# Altium schematic sheet Sheet2.SchDoc(Sheet2)
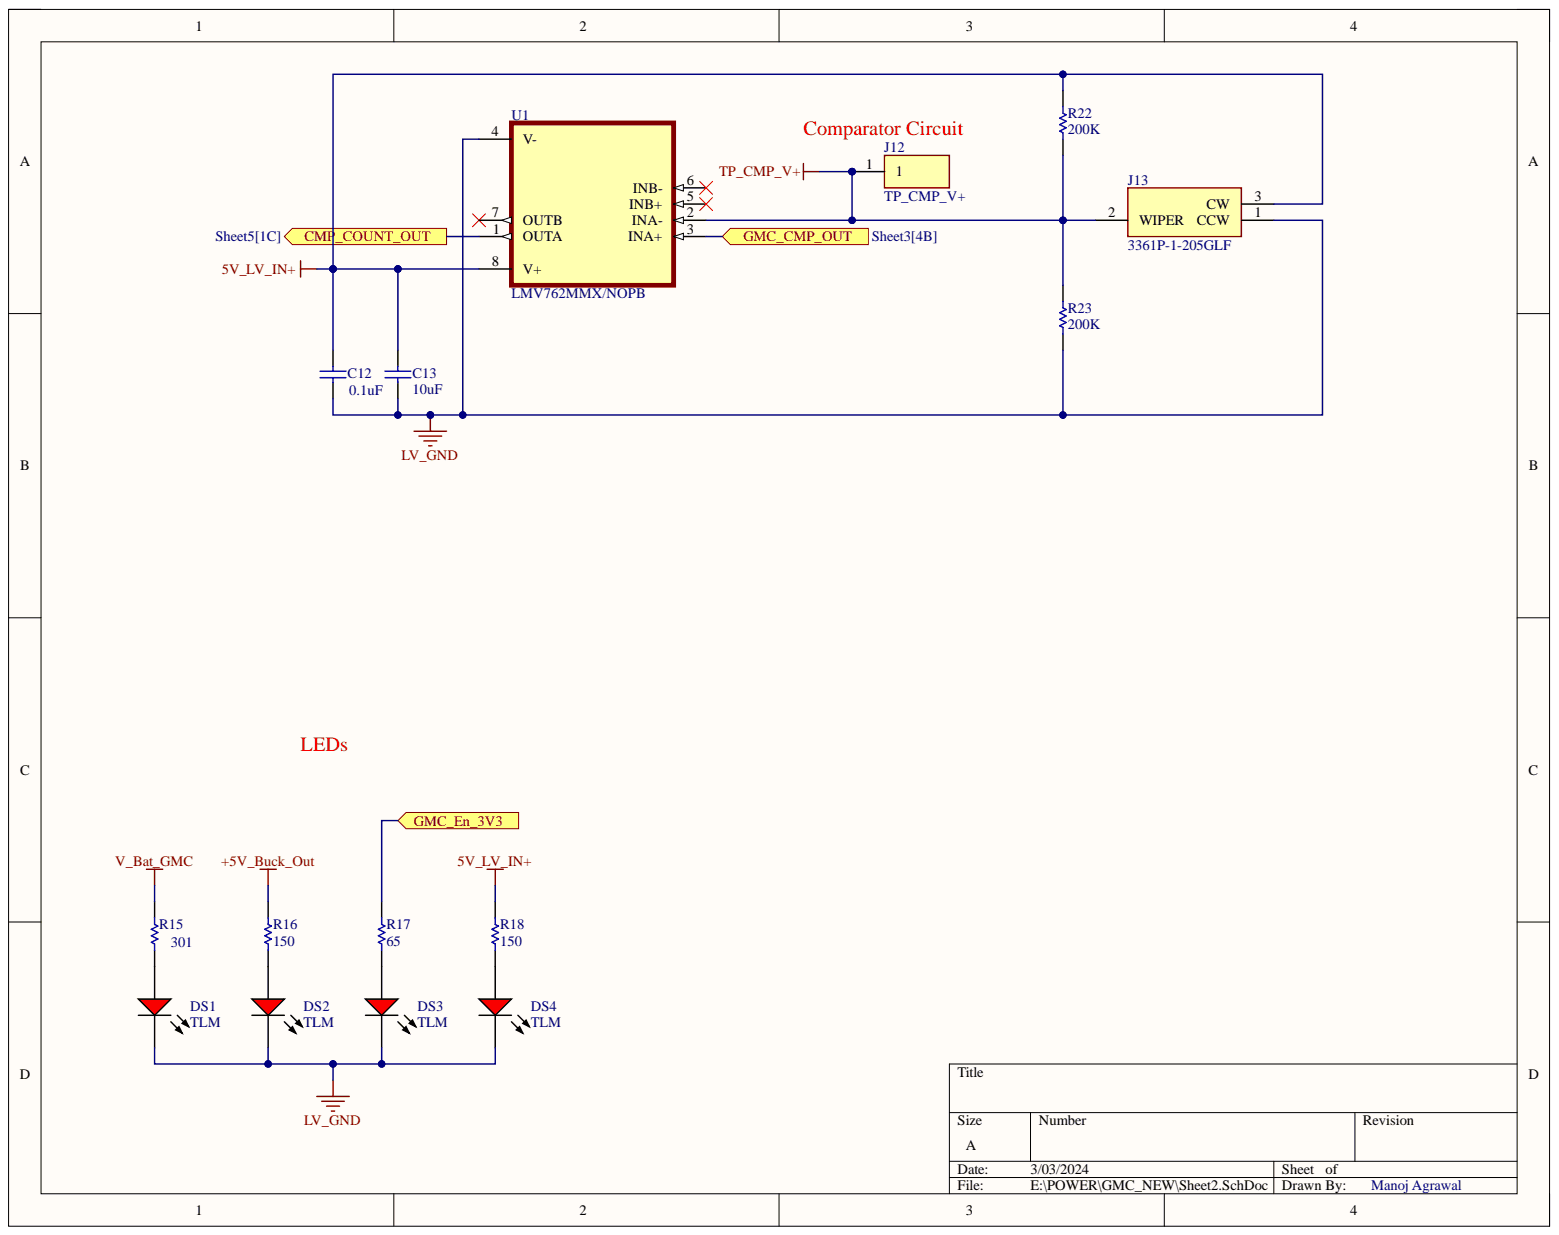
[diagram p0: Sheet2.SchDoc]

## Parts
C12  0.1uF  [CAPC2013X88X50LL20T25]
C13  10uF  [CAPC2013X88X50LL20T25]
DS1  TLM  [VISH-TLMX1100_V]
DS2  TLM  [VISH-TLMX1100_V]
DS3  TLM  [VISH-TLMX1100_V]
DS4  TLM  [VISH-TLMX1100_V]
J12  TP_CMP_V+  [HDRV1W64P0X254_1X1_254X248X838P]
J13  3361P-1-205GLF  [3361P1205GLF]
R15  301  [RESC2013X50X30LL20T20]
R16  150  [RESC2013X50X30LL20T20]
R17  65  [RESC2013X50X30LL20T20]
R18  150  [RESC2013X50X30LL20T20]
R22  200K  [RESC2013X50X30LL20T20]
R23  200K  [RESC2013X50X30LL20T20]
U1  LMV762MMX/NOPB  [SOP65P490X110-8N]

## Ports
CMP_COUNT_OUT, GMC_CMP_OUT, GMC_En_3V3

## Nets
5V_LV_IN+: C12.2 C13.2 J13.3 R16.2 R18.2 R22.1 U1.8(V+)
LV_GND: C12.1 C13.1 DS1.2 DS2.2 DS3.2 DS4.2 J13.1 R23.2 U1.4(V-)
TP_CMP_V+: J12.1 J13.2 R22.2 R23.1 U1.2(INA-)
V_Bat_GMC: R15.2
CMP_COUNT_OUT: U1.1(OUTA) ; port CMP_COUNT_OUT
GMC_CMP_OUT: U1.3(INA+) ; port GMC_CMP_OUT
GMC_En_3V3: R17.2 ; port GMC_En_3V3
NetDS1_1: DS1.1 R15.1
NetDS2_1: DS2.1 R16.1
NetDS3_1: DS3.1 R17.1
NetDS4_1: DS4.1 R18.1

Not connected: U1 5(INB+) 6(INB-) 7(OUTB)

## Library data of the parts
C12 C13 = CMP-2007-00266-1: Manufacturer: Kemet; Part Number: C0805C103K5RACTU; Component Kind: Standard; Library Name: Altium Content Vault
DS1-DS4 = CMP-1666-00027-1: LED, RED, 2.1 V, -40 to 100 degC, 2-Pin SMD (0603), RoHS, Tape and Reel; Capacitance: 12 pF; Resistance: 100000000000 Ohm; Tolerance: 5%; Type (Capacitors): C0G; Voltage Rating: 50 V; Case Code (Imperial): 0603; Case Code (Metric): 1608; Case/Package: 0603; Component Kind: Standard; ComponentLink1Description: Manufacturer URL; ComponentLink1URL: http://www.vishay.com/; ComponentLink2Description: Datasheet; ComponentLink2URL: http://www.vishay.com/docs/83173/tlmb1100.pdf; DatasheetVersion: Rev. 2.7, 03/2016; Height: 0.8 mm; Length: 1.6 mm; Library Name: Altium Content Vault; Max Operating Temperature: 125degC; Min Operating Temperature: -55degC; Mount: Surface Mount; Package Quantity: 4000; PackageDescription: 2-Pin Surface Mount Device, Body 1.6 x 0.8 mm; PackageReference: TLMX1100; Packaging: Tape and Reel; Pins: 2; Radiation Hardening: No; REACH SVHC: No SVHC; RoHSCompliant: True; Voltage Rating (DC): 50 V; Width: 0.8 mm
J12 = HTSW-101-07-L-S: Connector; Manufacturer_Name: SAMTEC; Arrow Part Number: HTSW-101-07-L-S; Arrow Price/Stock: https://www.arrow.com/en/products/htsw-101-07-l-s/samtec; Component Kind: Standard; Datasheet Link: https://www.arrow.com/en/products/htsw-101-07-l-s/samtec; Height: 8.38mm; Library Name: OBC3.0.SchLib; Manufacturer_Part_Number: HTSW-101-07-L-S; Mouser Part Number: 200-HTSW10107LS; Mouser Price/Stock: https://www.mouser.co.uk/ProductDetail/Samtec/HTSW-101-07-L-S?qs=0lQeLiL1qybicCfG80ZrYQ%3D%3D
J13 = 3361P-1-205GLF: Connector; Manufacturer_Name: Bourns; Component Kind: Standard; Datasheet Link: https://componentsearchengine.com/Datasheets/1/3361P-1-205GLF.pdf; Height: 5.84mm; Manufacturer_Part_Number: 3361P-1-205GLF; Mouser Part Number: 652-3361P-1-205GLF; Mouser Price/Stock: https://www.mouser.co.uk/ProductDetail/Bourns/3361P-1-205GLF?qs=ia4AKmiMHMr19OwPydiPPg%3D%3D
R15-R18 R22 R23 = CMP-2001-04896-1: Manufacturer: Vishay Dale; Part Number: CRCW08050000Z0EA; Component Kind: Standard; Library Name: Altium Content Vault
U1 = CMP-006-000000-1: Availability: Unavailable; Component Kind: Standard; Component Type: Standard; Design Item ID: LMV762MMX/NOPB; MP: LMV762MMX/NOPB; Package: None; Pin Count: 8; Price: None; Source: LMV762MMX_NOPB.SchLib; Library Name: AGRAWALMANOJ
